# Altium schematic sheet Power_3V3.SchDoc("Power 3V3")
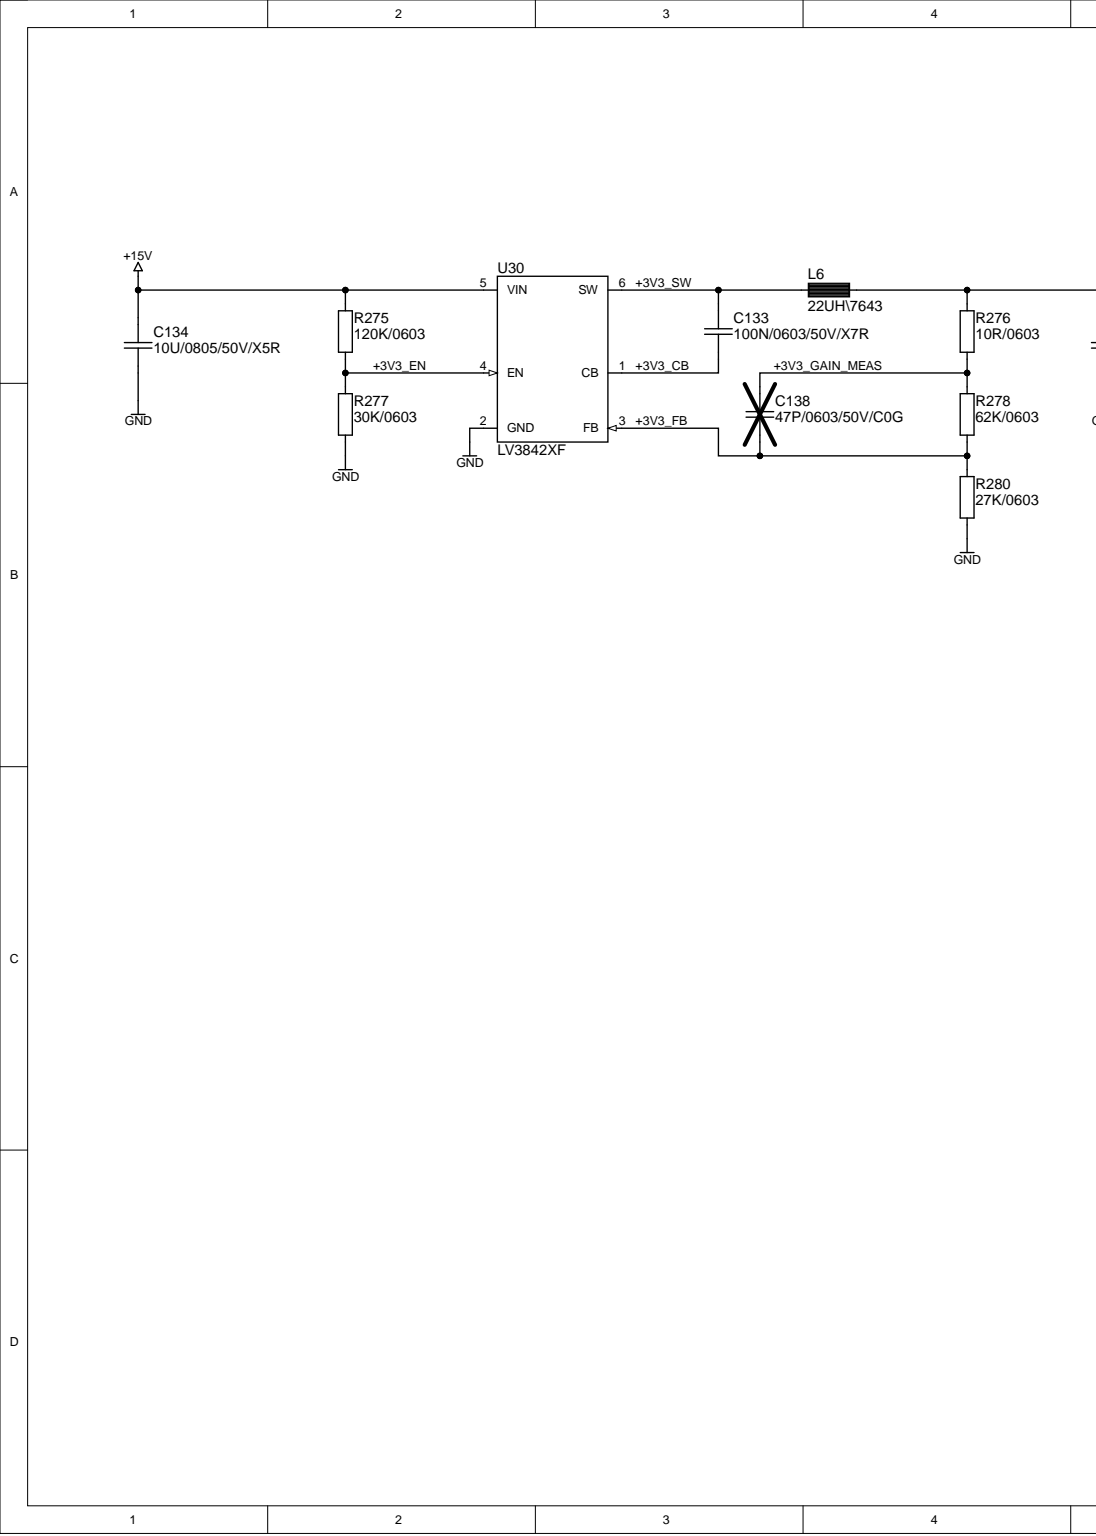
[diagram p0: Power_3V3.SchDoc, left]
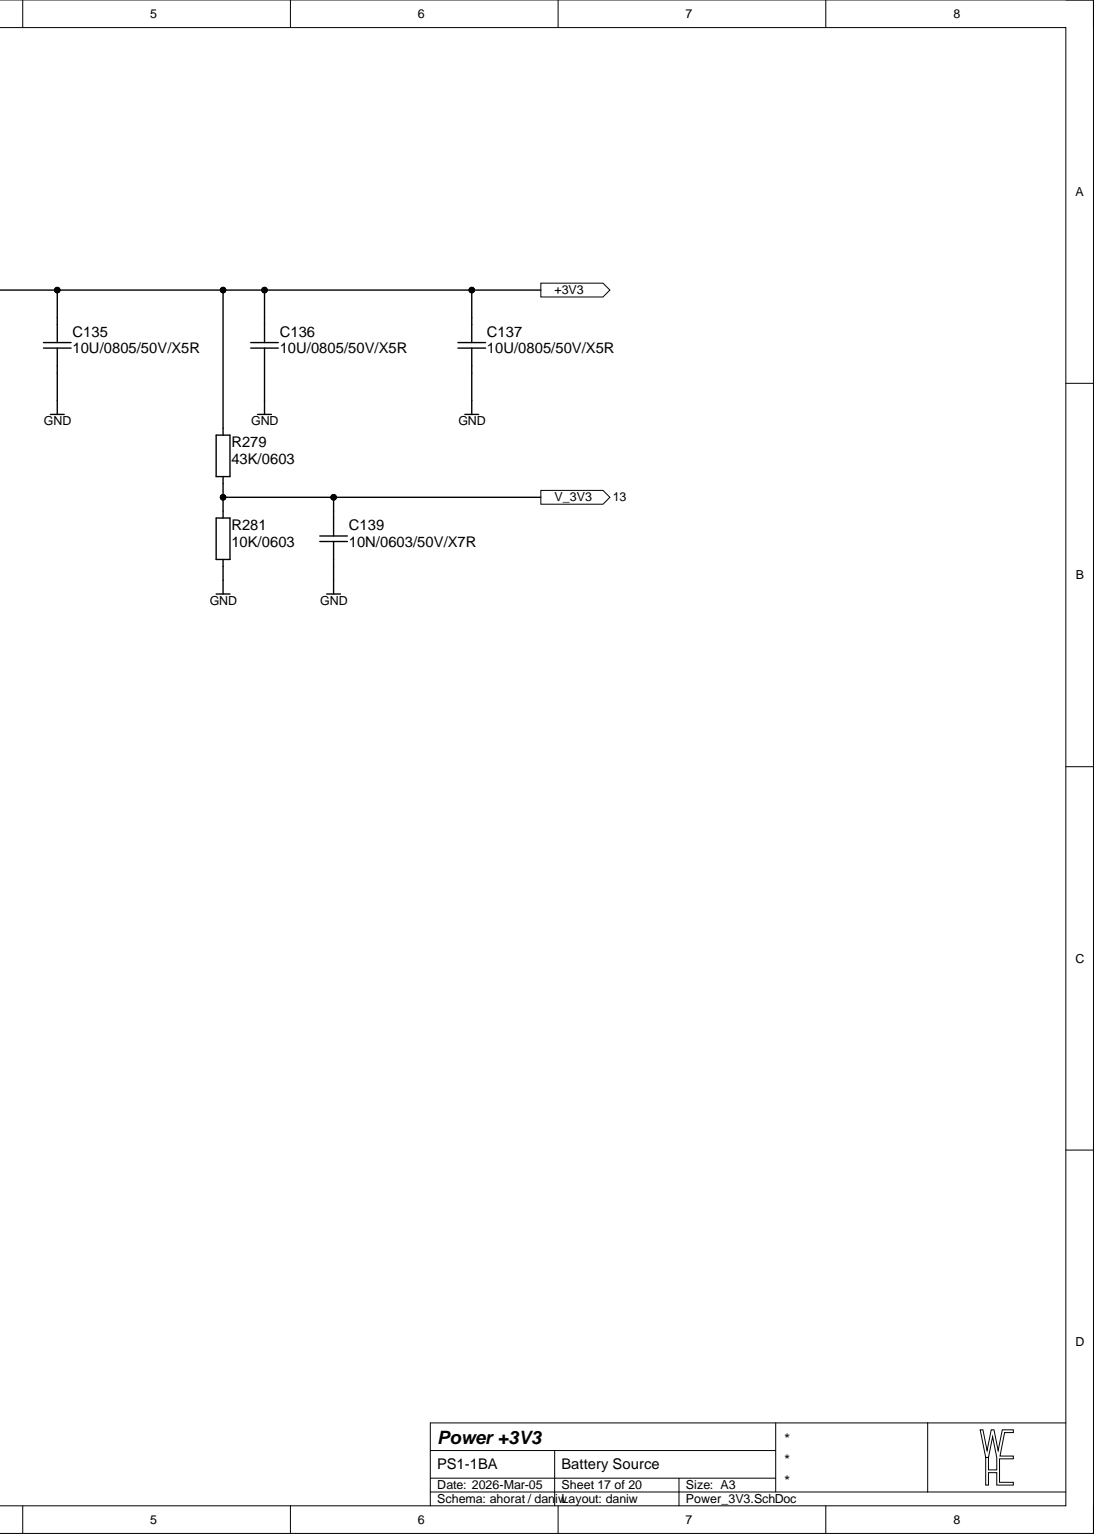
[diagram p1: Power_3V3.SchDoc, right]

## Parts
C133  100N/0603/50V/X7R  [CAP0603_X7R]
C134  10U/0805/50V/X5R  [CAP0805_X7R]
C135  10U/0805/50V/X5R  [CAP0805_X7R]
C136  10U/0805/50V/X5R  [CAP0805_X7R]
C137  10U/0805/50V/X5R  [CAP0805_X7R]
C138  47P/0603/50V/C0G  [CAP0603_C0G]
C139  10N/0603/50V/X7R  [CAP0603_X7R]
L6  22UH\7643  [INDM7676X43]
R275  120K/0603  [R0603-0.40-WW]
R276  10R/0603  [R0603-0.40-WW]
R277  30K/0603  [R0603-0.40-WW]
R278  62K/0603  [R0603-0.40-WW]
R279  43K/0603  [R0603-0.40-WW]
R280  27K/0603  [R0603-0.40-WW]
R281  10K/0603  [R0603-0.40-WW]
U30  LV3842XF  [SOT2395P280X125-6-NW]

## Ports
+3V3, V_3V3

## Nets
+15V: C134.1 R275.1 U30.5(VIN)
GND: C134.2 C135.2 C136.2 C137.2 C139.2 R277.2 R280.2 R281.2 U30.2(GND)
+3V3: C135.1 C136.1 C137.1 L6.2 R276.1 R279.1 ; port +3V3
+3V3_CB: C133.2 U30.1(CB)
+3V3_EN: R275.2 R277.1 U30.4(EN)
+3V3_FB: C138.2 R278.2 R280.1 U30.3(FB)
+3V3_GAIN_MEAS: C138.1 R276.2 R278.1
+3V3_SW: C133.1 L6.1 U30.6(SW)
V_3V3: C139.1 R279.2 R281.1 ; port V_3V3

## Library data of the parts
C133 = 100N/0603/50V/X7R: CAP SM 0603 100nF 50V X7R; Manufacturer: GENERIC; Manufacturer 1: YAGEO; Manufacturer Part Number 1: CC0603KPX7R9BB104; Absolute Value: 1E-7; Database Table Name: C$; Eurocircuits GPN: GPC0603104; Component Kind: Standard; HelpURL: https://www.yageo.com/upload/media/product/app/datasheet/mlcc/upy-gphc_x7r_6_3v-to-250v.pdf; JLC Type: Basic; Manufacturer 2: YAGEO; Manufacturer Part Number 2: CC0603MRX7R9BB104; Manufacturer Part Number 3: CC0603KRX7R9BB104; Package: 0603; Part Number: 100N/0603/50V/X7R; Supplier 1: Digi-Key; Supplier 2: Digi-Key; Supplier 3: LCSC; Supplier Part Number 1: 311-3567-1-ND; Supplier Part Number 2: 311-4110-1-ND; Supplier Part Number 3: C14663; Value: 100nF; Library Name: daniw_altium_lib.DbLib
C134-C137 = 10U/0805/50V/X5R: CAP SM 0805 10uF 50V X5R; Manufacturer: GENERIC; Manufacturer 1: Murata Electronics; Manufacturer Part Number 1: GRM21BR61H106KE43K; Absolute Value: 1E-5; Database Table Name: C$; Eurocircuits GPN: GPN0805106-50-X5R; Component Kind: Standard; HelpURL: https://search.murata.co.jp/Ceramy/image/img/A01X/G101/ENG/GRM21BR61H106KE43-01A.pdf; JLC Type: Basic; Manufacturer Part Number 3: GRM21BR61H106KE43L; Package: 0805; Part Number: 10U/0805/50V/X5R; Supplier 1: Digi-Key; Supplier 3: LCSC; Supplier Part Number 1: 490-GRM21BR61H106KE43KCT-ND; Supplier Part Number 3: C440198; Value: 10uF; Library Name: daniw_altium_lib.DbLib
C138 = 47P/0603/50V/C0G: CAP SM 0603 47pF 50V C0G; Manufacturer: GENERIC; Manufacturer 1: YAGEO; Manufacturer Part Number 1: CC0603JRNPO9BN470; Absolute Value: 4.7E-11; Database Table Name: C$; Eurocircuits GPN: GPC0603470-C0G; Component Kind: Standard; HelpURL: https://www.yageo.com/upload/media/product/productsearch/datasheet/mlcc/UPY-GP_NP0_16V-to-50V_18.pdf; JLC Type: Basic; Manufacturer 2: YAGEO; Manufacturer Part Number 2: CC0603KRNPO9BN470; Manufacturer Part Number 3: CL10C470JB8NNNC; Package: 0603; Part Number: 47P/0603/50V/C0G; Supplier 1: Digi-Key; Supplier 2: Digi-Key; Supplier 3: LCSC; Supplier Part Number 1: 311-1065-1-ND; Supplier Part Number 2: 311-4037-1-ND; Supplier Part Number 3: C1671; Value: 47pF; Library Name: daniw_altium_lib.DbLib
C139 = 10N/0603/50V/X7R: CAP SM 0603 10nF 50V X7R; Manufacturer: GENERIC; Manufacturer 1: YAGEO; Manufacturer Part Number 1: CC0603KPX7R9BB103; Absolute Value: 1E-8; Database Table Name: C$; Eurocircuits GPN: GPC0603103; Component Kind: Standard; HelpURL: https://www.yageo.com/upload/media/product/app/datasheet/mlcc/upy-gphc_x7r_6_3v-to-250v.pdf; Manufacturer 2: YAGEO; Manufacturer Part Number 2: CC0603KRX7R9BB103; Manufacturer Part Number 3: CC0603KRX7R9BB103; Package: 0603; Part Number: 10N/0603/50V/X7R; Supplier 1: Digi-Key; Supplier 2: Digi-Key; Supplier 3: LCSC; Supplier Part Number 1: 311-1572-1-ND; Supplier Part Number 2: 311-1085-1-ND; Supplier Part Number 3: C100042; Value: 10nF; Library Name: daniw_altium_lib.DbLib
L6 = 22UH\7643: FIXED IND 22UH 1.75A 92.5MOHM SM; Manufacturer: Eaton - Electronics Division; Manufacturer Part Number 1: DR74-220-R; Absolute Value: 2.2E-5; Database Table Name: L$; Component Kind: Standard; HelpURL: https://www.eaton.com/content/dam/eaton/products/electronic-components/resources/data-sheet/eaton-dr-high-power-density-high-efficiency-shielded-drum-core-power-inductors-data-sheet.pdf; Manufacturer 1: Eaton - Electronics Division; Manufacturer 3: SXN(Shun Xiang Nuo Elec); Manufacturer Part Number 3: SMDRI74-220M; Package: 7643; Part Number: 22UH\7643; Supplier 1: Digi-Key; Supplier 3: LCSC; Supplier Part Number 1: 513-1390-1-ND; Supplier Part Number 3: C164083; Value: 22UH; Library Name: daniw_altium_lib.DbLib
R275 = 120K/0603: RES SM 0603 120K; Manufacturer: GENERIC; Manufacturer 1: YAGEO; Manufacturer Part Number 1: RC0603FR-13120KL; Absolute Value: 120000; Database Table Name: R$; Eurocircuits GPN: GPR0603120K; Component Kind: Standard; HelpURL: https://www.yageogroup.com/content/datasheet/asset/file/PYU-RC_GROUP_51_ROHS_L; JLC Type: Basic; Manufacturer 2: YAGEO; Manufacturer 3: UNI-ROYAL(Uniroyal Elec); Manufacturer Part Number 2: RC0603FR-10120KL; Manufacturer Part Number 3: 0603WAF1203T5E; Package: 0603; Part Number: 120K/0603; Supplier 1: Digi-Key; Supplier 2: Digi-Key; Supplier 3: LCSC; Supplier Part Number 1: 13-RC0603FR-13120KLCT-ND; Supplier Part Number 2: 13-RC0603FR-10120KLCT-ND; Supplier Part Number 3: C25808; Value: 120K; Library Name: daniw_altium_lib.DbLib
R276 = 10R/0603: RES SM 0603 10R; Manufacturer: GENERIC; Manufacturer 1: YAGEO; Manufacturer Part Number 1: RC0603FR-1310RL; Absolute Value: 10; Database Table Name: R$; Eurocircuits GPN: GPR060310R; Component Kind: Standard; HelpURL: https://www.yageogroup.com/content/datasheet/asset/file/PYU-RC_GROUP_51_ROHS_L; JLC Type: Basic; Manufacturer 2: YAGEO; Manufacturer 3: UNI-ROYAL; Manufacturer Part Number 2: RC0603FR-1010RL; Manufacturer Part Number 3: 0603WAF100JT5E; Package: 0603; Part Number: 10R/0603; Supplier 1: Digi-Key; Supplier 2: Digi-Key; Supplier 3: LCSC; Supplier Part Number 1: 13-RC0603FR-1310RLCT-ND; Supplier Part Number 2: 13-RC0603FR-1010RLCT-ND; Supplier Part Number 3: C22859; Value: 10R; Library Name: daniw_altium_lib.DbLib
R277 = 30K/0603: RES SM 0603 30K; Manufacturer: GENERIC; Manufacturer 1: YAGEO; Manufacturer Part Number 1: RC0603FR-1330KL; Absolute Value: 30000; Database Table Name: R$; Eurocircuits GPN: GPR060330K; Component Kind: Standard; HelpURL: https://www.yageogroup.com/content/datasheet/asset/file/PYU-RC_GROUP_51_ROHS_L; JLC Type: Basic; Manufacturer 2: YAGEO; Manufacturer 3: UNI-ROYAL(Uniroyal Elec); Manufacturer Part Number 2: RC0603FR-1030KL; Manufacturer Part Number 3: 0603WAF3002T5E; Package: 0603; Part Number: 30K/0603; Supplier 1: Digi-Key; Supplier 2: Digi-Key; Supplier 3: LCSC; Supplier Part Number 1: 13-RC0603FR-1330KLCT-ND; Supplier Part Number 2: 13-RC0603FR-1030KLCT-ND; Supplier Part Number 3: C22984; Value: 30K; Library Name: daniw_altium_lib.DbLib
R278 = 62K/0603: RES SM 0603 62K; Manufacturer: GENERIC; Manufacturer 1: YAGEO; Manufacturer Part Number 1: RC0603FR-1362KL; Absolute Value: 62000; Database Table Name: R$; Eurocircuits GPN: GPR060362K; Component Kind: Standard; HelpURL: https://www.yageogroup.com/content/datasheet/asset/file/PYU-RC_GROUP_51_ROHS_L; JLC Type: Extended; Manufacturer 2: YAGEO; Manufacturer 3: UNI-ROYAL(Uniroyal Elec); Manufacturer Part Number 2: RC0603FR-1062KL; Manufacturer Part Number 3: 0603WAF6202T5E; Package: 0603; Part Number: 62K/0603; Supplier 1: Digi-Key; Supplier 2: Digi-Key; Supplier 3: LCSC; Supplier Part Number 1: 13-RC0603FR-1362KLCT-ND; Supplier Part Number 2: 13-RC0603FR-1062KLCT-ND; Supplier Part Number 3: C23221; Value: 62K; Library Name: daniw_altium_lib.DbLib
R279 = 43K/0603: RES SM 0603 43K; Manufacturer: GENERIC; Manufacturer 1: YAGEO; Manufacturer Part Number 1: RC0603FR-1343KL; Absolute Value: 43000; Database Table Name: R$; Eurocircuits GPN: GPR060343K; Component Kind: Standard; HelpURL: https://www.yageogroup.com/content/datasheet/asset/file/PYU-RC_GROUP_51_ROHS_L; JLC Type: Extended; Manufacturer 2: YAGEO; Manufacturer 3: YAGEO; Manufacturer Part Number 2: RC0603FR-1043KL; Manufacturer Part Number 3: RC0603FR-0743KL; Package: 0603; Part Number: 43K/0603; Supplier 1: Digi-Key; Supplier 2: Digi-Key; Supplier 3: LCSC; Supplier Part Number 1: 13-RC0603FR-1343KLCT-ND; Supplier Part Number 2: 13-RC0603FR-1043KLCT-ND; Supplier Part Number 3: C137719; Value: 43K; Library Name: daniw_altium_lib.DbLib
R280 = 27K/0603: RES SM 0603 27K; Manufacturer: GENERIC; Manufacturer 1: YAGEO; Manufacturer Part Number 1: RC0603FR-1327KL; Absolute Value: 27000; Database Table Name: R$; Eurocircuits GPN: GPR060327K; Component Kind: Standard; HelpURL: https://www.yageogroup.com/content/datasheet/asset/file/PYU-RC_GROUP_51_ROHS_L; JLC Type: Basic; Manufacturer 2: YAGEO; Manufacturer 3: UNI-ROYAL(Uniroyal Elec); Manufacturer Part Number 2: RC0603FR-1027KL; Manufacturer Part Number 3: 0603WAF2702T5E; Package: 0603; Part Number: 27K/0603; Supplier 1: Digi-Key; Supplier 2: Digi-Key; Supplier 3: LCSC; Supplier Part Number 1: 13-RC0603FR-1327KLCT-ND; Supplier Part Number 2: 13-RC0603FR-1027KLCT-ND; Supplier Part Number 3: C22967; Value: 27K; Library Name: daniw_altium_lib.DbLib
R281 = 10K/0603: RES SM 0603 10K; Manufacturer: GENERIC; Manufacturer 1: YAGEO; Manufacturer Part Number 1: RC0603FR-1310KL; Absolute Value: 10000; Database Table Name: R$; Eurocircuits GPN: GPR060310K; Component Kind: Standard; HelpURL: https://www.yageogroup.com/content/datasheet/asset/file/PYU-RC_GROUP_51_ROHS_L; JLC Type: Basic; Manufacturer 2: YAGEO; Manufacturer 3: UNI-ROYAL(Uniroyal Elec); Manufacturer Part Number 2: RC0603FR-1010KL; Manufacturer Part Number 3: 0603WAF1002T5E; Package: 0603; Part Number: 10K/0603; Supplier 1: Digi-Key; Supplier 2: Digi-Key; Supplier 3: LCSC; Supplier Part Number 1: 13-RC0603FR-1310KLCT-ND; Supplier Part Number 2: 13-RC0603FR-1010KLCT-ND; Supplier Part Number 3: C25804; Value: 10K; Library Name: daniw_altium_lib.DbLib
U30 = LV3842XF: 4-V to 36-V, 600-mA, Synchronous Step-Down Converter; Manufacturer: Texas Instruments; Manufacturer Part Number 1: LV3842XFDBVR; Database Table Name: Integrated$; Component Kind: Standard; HelpURL: https://www.ti.com/lit/ds/symlink/lv3842.pdf; Manufacturer 1: Texas Instruments; Manufacturer 3: Texas Instruments; Manufacturer Part Number 3: LV3842XFDBVR; Package: SOT23; Part Number: LV3842XF; Supplier 1: Digi-Key; Supplier 3: LCSC; Supplier Part Number 1: 296-LV3842XFDBVR-ND; Supplier Part Number 3: C22428295; Library Name: daniw_altium_lib.DbLib
